# Altium schematic sheet Licznik_sekund.SchDoc
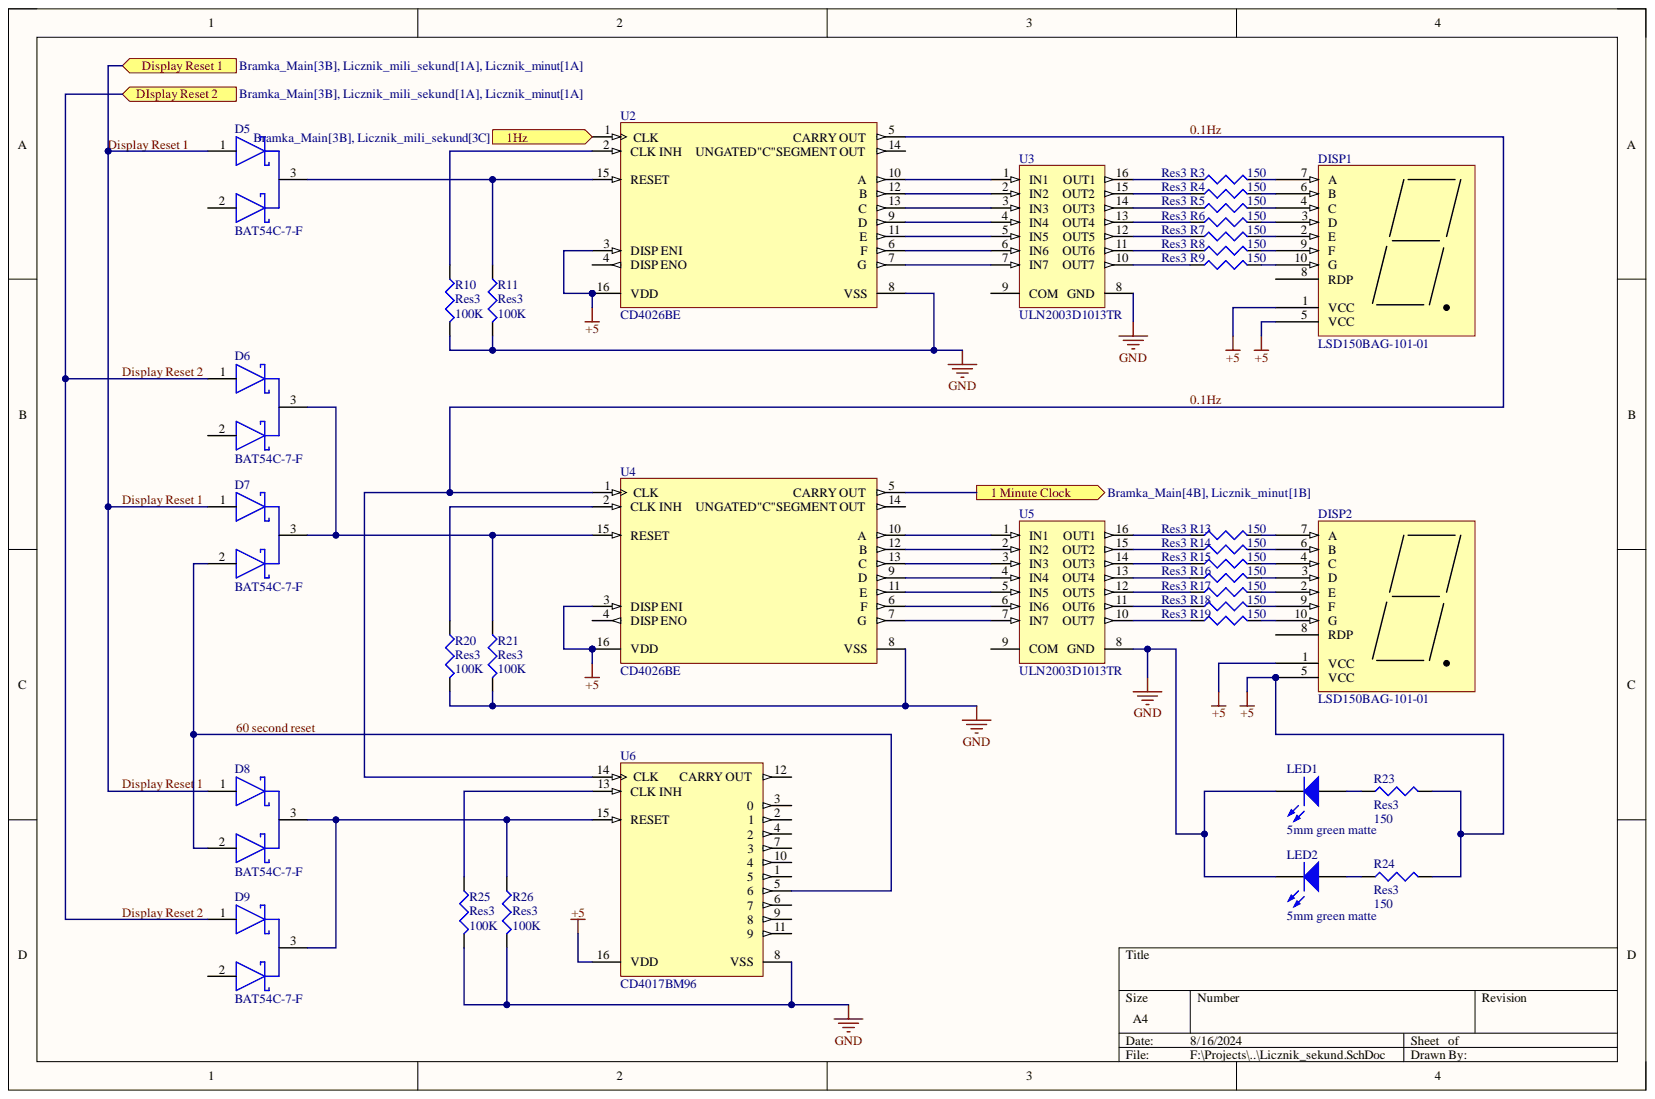
[diagram p0: Licznik_sekund.SchDoc]

## Parts
D5  BAT54C-7-F  [FP-SOT23-IPC_B]
D6  BAT54C-7-F  [FP-SOT23-IPC_B]
D7  BAT54C-7-F  [FP-SOT23-IPC_B]
D8  BAT54C-7-F  [FP-SOT23-IPC_B]
D9  BAT54C-7-F  [FP-SOT23-IPC_B]
DISP1  LSD150BAG-101-01  [7 segment display]
DISP2  LSD150BAG-101-01  [7 segment display]
LED1  5mm green matte  [Type 5mm]
LED2  5mm green matte  [Type 5mm]
R3  Res3  value 150  [6-0805_M]
R4  Res3  value 150  [6-0805_M]
R5  Res3  value 150  [6-0805_M]
R6  Res3  value 150  [6-0805_M]
R7  Res3  value 150  [6-0805_M]
R8  Res3  value 150  [6-0805_M]
R9  Res3  value 150  [6-0805_M]
R10  Res3  value 100K  [J1-0603]
R11  Res3  value 100K  [J1-0603]
R13  Res3  value 150  [6-0805_M]
R14  Res3  value 150  [6-0805_M]
R15  Res3  value 150  [6-0805_M]
R16  Res3  value 150  [6-0805_M]
R17  Res3  value 150  [6-0805_M]
R18  Res3  value 150  [6-0805_M]
R19  Res3  value 150  [6-0805_M]
R20  Res3  value 100K  [J1-0603]
R21  Res3  value 100K  [J1-0603]
R23  Res3  value 150  [6-0805_M]
R24  Res3  value 150  [6-0805_M]
R25  Res3  value 100K  [J1-0603]
R26  Res3  value 100K  [J1-0603]
U2  CD4026BE  [N0016A]
U3  ULN2003D1013TR  [STM-SO-16_M]
U4  CD4026BE  [N0016A]
U5  ULN2003D1013TR  [STM-SO-16_M]
U6  CD4017BM96  [D0016A_L]

## Ports
1 Minute Clock, 1Hz, Display Reset 1, DIsplay Reset 2

## Nets
+5: DISP1.1(VCC) DISP1.5(VCC) DISP2.1(VCC) DISP2.5(VCC) R23.2 R24.2 U2.3(DISP_ENI) U2.16(VDD) U4.3(DISP_ENI) U4.16(VDD) U6.16(VDD)
GND: LED1.1 LED2.1 R10.2 R11.2 R20.2 R21.2 R25.2 R26.2 U2.8(VSS) U3.8(GND) U4.8(VSS) U5.8(GND) U6.8(VSS)
0.1Hz: U2.5(CARRY_OUT) U4.1(CLK) U6.14(CLK)
1 Minute Clock: U4.5(CARRY_OUT) ; port 1 Minute Clock
1Hz: U2.1(CLK) ; port 1Hz
60 second reset: D7.2 D8.2 U6.5
Display Reset 1: D5.1 D7.1 D8.1 ; port Display Reset 1
Display Reset 2: D6.1 D9.1 ; port DIsplay Reset 2
NetD5_2: D5.2
NetD5_3: D5.3 R11.1 U2.15(RESET)
NetD6_2: D6.2
NetD6_3: D6.3 D7.3 R21.1 U4.15(RESET)
NetD8_3: D8.3 D9.3 R26.1 U6.15(RESET)
NetD9_2: D9.2
NetDISP1_2: DISP1.2(E) R7.2
NetDISP1_3: DISP1.3(D) R6.2
NetDISP1_4: DISP1.4(C) R5.2
NetDISP1_6: DISP1.6(B) R4.2
NetDISP1_7: DISP1.7(A) R3.2
NetDISP1_8: DISP1.8(RDP)
NetDISP1_9: DISP1.9(F) R8.2
NetDISP1_10: DISP1.10(G) R9.2
NetDISP2_2: DISP2.2(E) R17.2
NetDISP2_3: DISP2.3(D) R16.2
NetDISP2_4: DISP2.4(C) R15.2
NetDISP2_6: DISP2.6(B) R14.2
NetDISP2_7: DISP2.7(A) R13.2
NetDISP2_8: DISP2.8(RDP)
NetDISP2_9: DISP2.9(F) R18.2
NetDISP2_10: DISP2.10(G) R19.2
NetLED1_2: LED1.2 R23.1
NetLED2_2: LED2.2 R24.1
NetR3_1: R3.1 U3.16(OUT1)
NetR4_1: R4.1 U3.15(OUT2)
NetR5_1: R5.1 U3.14(OUT3)
NetR6_1: R6.1 U3.13(OUT4)
NetR7_1: R7.1 U3.12(OUT5)
NetR8_1: R8.1 U3.11(OUT6)
NetR9_1: R9.1 U3.10(OUT7)
NetR10_1: R10.1 U2.2(CLK_INH)
NetR13_1: R13.1 U5.16(OUT1)
NetR14_1: R14.1 U5.15(OUT2)
NetR15_1: R15.1 U5.14(OUT3)
NetR16_1: R16.1 U5.13(OUT4)
NetR17_1: R17.1 U5.12(OUT5)
NetR18_1: R18.1 U5.11(OUT6)
NetR19_1: R19.1 U5.10(OUT7)
NetR20_1: R20.1 U4.2(CLK_INH)
NetR25_1: R25.1 U6.13(CLK_INH)
NetU2_4: U2.4(DISP_ENO)
NetU2_6: U2.6(F) U3.6(IN6)
NetU2_7: U2.7(G) U3.7(IN7)
NetU2_9: U2.9(D) U3.4(IN4)
NetU2_10: U2.10(A) U3.1(IN1)
NetU2_11: U2.11(E) U3.5(IN5)
NetU2_12: U2.12(B) U3.2(IN2)
NetU2_13: U2.13(C) U3.3(IN3)
NetU2_14: U2.14(UNGATED"C"SEGMENT_OUT)
NetU3_9: U3.9(COM)
NetU4_4: U4.4(DISP_ENO)
NetU4_6: U4.6(F) U5.6(IN6)
NetU4_7: U4.7(G) U5.7(IN7)
NetU4_9: U4.9(D) U5.4(IN4)
NetU4_10: U4.10(A) U5.1(IN1)
NetU4_11: U4.11(E) U5.5(IN5)
NetU4_12: U4.12(B) U5.2(IN2)
NetU4_13: U4.13(C) U5.3(IN3)
NetU4_14: U4.14(UNGATED"C"SEGMENT_OUT)
NetU5_9: U5.9(COM)
NetU6_1: U6.1
NetU6_2: U6.2
NetU6_3: U6.3
NetU6_4: U6.4
NetU6_6: U6.6
NetU6_7: U6.7
NetU6_9: U6.9
NetU6_10: U6.10
NetU6_11: U6.11
NetU6_12: U6.12(CARRY_OUT)

## Library data of the parts
D5-D9 = CMP-12790-000084-1: DIODE ARRAY SCHOTTKY 30V SOT23-3; Average Rectified Current: 200mA; Capacitance: 10pF; Element Configuration: Common Cathode; Forward Voltage: 800mV; Max Forward Surge Current (Ifsm): 600mA; Max Power Dissipation: 200mW; Max Reverse Current: 2uA; Reverse Recovery Time: 5ns; Case/Package: SOT-23; Component Kind: Standard; Contact Plating: Tin; Current Rating: 200mA; Forward Current: 200mA; Height: 1mm; Lead Free: Lead Free; Length: 2.9mm; Library Name: Altium Content Vault; Max Operating Temperature: 150°C; Max Repetitive Reverse Voltage (Vrrm): 30V; Max Reverse Voltage (DC): 30V; Min Operating Temperature: -65°C; Mount: Surface Mount; Number of Pins: 3; Package Quantity: 3000; Packaging: Tape and Reel; Peak Non-Repetitive Surge Current: 600mA; Power Dissipation: 200mW; Power Rating: 200mW; Radiation Hardening: No; REACH SVHC: No SVHC; RoHS Compliant: Yes; Series: -; Termination: SMD/SMT; Voltage Rating (DC): 30V; Weight: 0.000282oz; Width: 1.3mm
DISP1 DISP2 = CMP-007-00036-2: 7 segment display 1.5 inch; Component Kind: Standard; Library Name: WUST
LED1 LED2 = CMP-1488-00007-1: THT LED round mono-color color lens, WL-TMRW, Bright Green; Manufacturer: Wuerth Elektronik; PartNumber: 151051VS04000; Component Kind: Standard; ComponentLink1Description: Manufacturer URL; ComponentLink1URL: http://www.we-online.com; ComponentLink2Description: Datasheet; ComponentLink2URL: http://katalog.we-online.de/pbs/datasheet/7448042001.pdfhttp://katalog.we-online.de/pbs/datasheet/7448042001.pdfhttp://katalog.we-online.de/pbs/datasheet/7448042001.pdfhttp://katalog.we-online.de/pbs/datasheet/151051VS04000.pdf; Emitting color: Bright Green; Library Name: Altium Content Vault; PackageReference: Type 5mm
R3-R11 R13-R21 R23-R26 = Res3: Resistor; Component Kind: Standard; LatestRevisionDate: 29-May-2009; LatestRevisionNote: IPC-7351 Footprint Added.; Library Name: Miscellaneous Devices.IntLib; PackageDescription: Chip Resistor; PackageReference: J1-0603; Published: 8-Jun-2000; Signal Integrity: Res; Simulation: RESISTOR; Publisher: Altium Limited
U2 U4 = CMP-1623-00561-2: CMOS Decade Counter/Divider with Decoded 7-Segment Display Outputs and Display Enable, N0016A, TUBE; Manufacturer: Texas Instruments; Bits(#): 7; F @ Nom Voltage(Max)(Mhz): 8; ICC @ Nom Voltage(Max)(mA): 0.03; Inputs: 1; Output Drive (IOL/IOH)(Max)(mA): 1.5/-1.5; Rating: Catalog; Schmitt Trigger: No; Technology Family: CD4000; tpd @ Nom Voltage(Max)(ns): 250; VCC(Max)(V): 18; VCC(Min)(V): 3; Voltage(Nom)(V): 5,10,15; Code_JEDEC: MS-001; Component Kind: Standard; DatasheetVersion: SCHS031B; Function: Counter; Generic Part Number: CD4026B; Input Type: CMOS; Library Name: Altium Content Vault; Operating Temperature Range(C): -55 to 125; Output Type: CMOS; PackageReference: N0016A; Type: Decade
U3 U5 = CMP-2000-05374-1: Seven NPN Darlington Array Transistor, 50 V, 500 mA, -40 to 85 degC, 8-Pin SOIC, RoHS, Tape and Reel; Manufacturer: STMicroelectronics; Collector Emitter Voltage V(br)ceo: 50V; DC Collector Current: 500mA; DC Current Gain hFE: 1000hFE; No. of Pins: 16Pins; SVHC: NoSVHC(17-Dec-2015); Automotive Qualification Standard: -; Component Kind: Standard; Datasheet URL: https://datasheet.ciiva.com/1193/1690348-1193460.pdf; Datasheet Version: Rev. 8, 06/2012; Library Name: Altium Content Vault; Manufacturer URL: http://www.st.com/; MSL: MSL1-Unlimited; Operating Temperature Max: 85degC; Package Description: 16-Pin Small Outline Integrated Circuit, Body 9.9 x 3.9 mm, Pitch 1.27 mm; Package Reference: SO-16; Power Dissipation Pd: -; Product Range: -; Transistor Case Style: SOIC; Transistor Polarity: NPN
U6 = CMP-1623-00072-2: CMOS Decade Counter with 10 Decoded Outputs, D0016A, LARGE T&R; Manufacturer: Texas Instruments; Bits(#): 10; F @ Nom Voltage(Max)(Mhz): 8; ICC @ Nom Voltage(Max)(mA): 0.03; Inputs: 1; Output Drive (IOL/IOH)(Max)(mA): 1.5/-1.5; Rating: Military; Schmitt Trigger: No; Technology Family: CD4000; tpd @ Nom Voltage(Max)(ns): 270; VCC(Max)(V): 18; VCC(Min)(V): 3; Code_JEDEC: MS-012 variation AC; Component Kind: Standard; DatasheetVersion: SCHS027C; Function: Counter; Generic Part Number: CD4017B; Input Type: CMOS; Library Name: Altium Content Vault; Operating Temperature Range(C): -55 to 125; Output Type: CMOS; PackageReference: D0016A; Type: Decade; Voltage(Nom)(V): 10
